# Altium schematic sheet Power.SchDoc(Power)
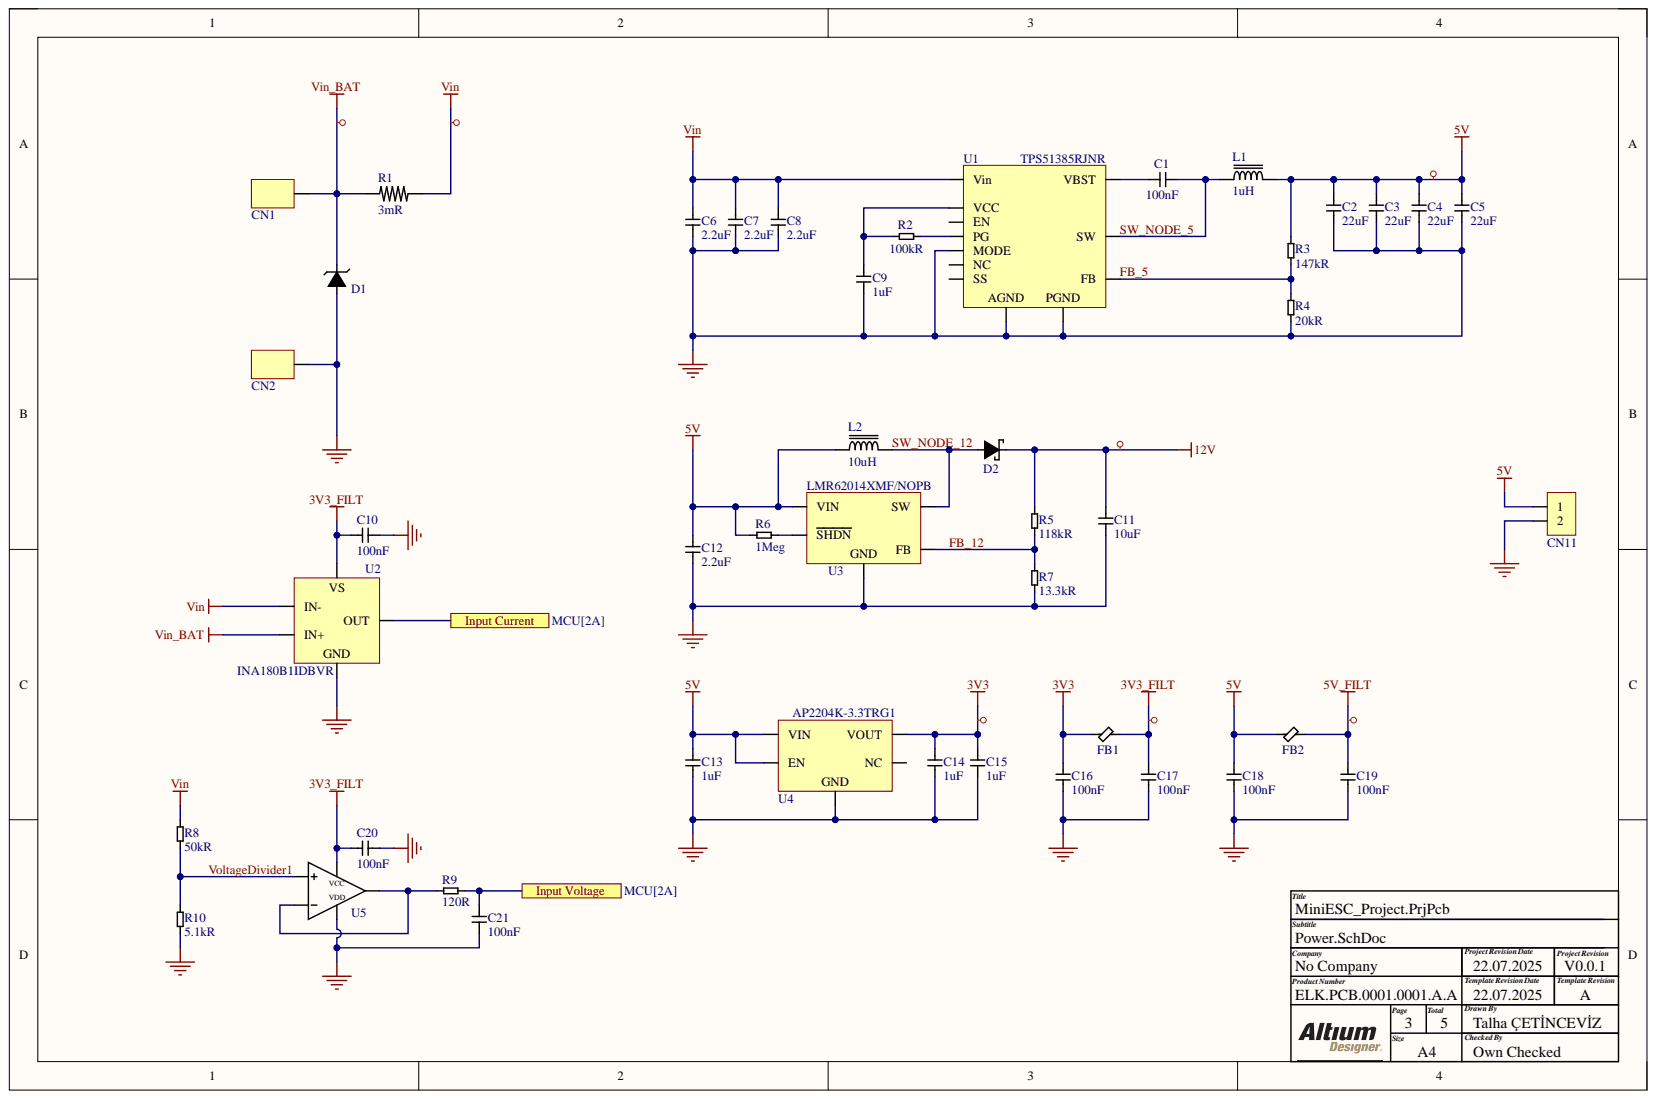
[diagram p0: Power.SchDoc]

## Parts
C1  value 100nF  [CAP 0805]  (hidden comment: CL21B104KBCNNNC)
C2  value 22uF  [CAP 1206]  (hidden comment: CL31B226KPHNNNE)
C3  value 22uF  [CAP 1206]  (hidden comment: CL31B226KPHNNNE)
C4  value 22uF  [CAP 1206]  (hidden comment: CL31B226KPHNNNE)
C5  value 22uF  [CAP 1206]  (hidden comment: CL31B226KPHNNNE)
C6  value 2.2uF  [CAP 1206]  (hidden comment: CL31B225KBHNNNE)
C7  value 2.2uF  [CAP 1206]  (hidden comment: CL31B225KBHNNNE)
C8  value 2.2uF  [CAP 1206]  (hidden comment: CL31B225KBHNNNE)
C9  value 1uF  [CAP 0805]  (hidden comment: CC0805KKX7R9BB105)
C10  value 100nF  [CAP 0603]  (hidden comment: CC0603KRX7R9BB104)
C11  value 10uF  [CAP 0805]  (hidden comment: CL21A106KAYNNNE)
C12  value 2.2uF  [CAP 1206]  (hidden comment: CL31B225KBHNNNE)
C13  value 1uF  [CAP 1206]  (hidden comment: CL31B105KOFNNNE)
C14  value 1uF  [CAP 1206]  (hidden comment: CL31B105KOFNNNE)
C15  value 1uF  [CAP 1206]  (hidden comment: CL31B105KOFNNNE)
C16  value 100nF  [CAP 0603]  (hidden comment: CC0603KRX7R9BB104)
C17  value 100nF  [CAP 0603]  (hidden comment: CC0603KRX7R9BB104)
C18  value 100nF  [CAP 0603]  (hidden comment: CC0603KRX7R9BB104)
C19  value 100nF  [CAP 0603]  (hidden comment: CC0603KRX7R9BB104)
C20  value 100nF  [CAP 0603]  (hidden comment: CC0603KRX7R9BB104)
C21  value 100nF  [CAP 0603]  (hidden comment: CC0603KRX7R9BB104)
CN1  SMD PAD 1  [SMD PAD 1]  (value not drawn)  (no BOM)
CN2  SMD PAD 1  [SMD PAD 1]  (value not drawn)  (no BOM)
CN11  2 Pin SMD  [2 Pin SMD]  (value not drawn)  (no BOM)
D1  SMAJ33CA-HT  [SMA]  (value not drawn)
D2  SL02-GS08  [DO-219AB]  (value not drawn)
FB1  BLM18EG601SN1D  [FB 0603]  (value not drawn)
FB2  BLM18EG601SN1D  [FB 0603]  (value not drawn)
L1  value 1uH  [11x10]  (hidden comment: MGAH10041R0M-10)
L2  value 10uH  [3.9x3.9]  (hidden comment: LPS4018-103MRC)
R1  value 3mR  [3920]  (hidden comment: BVS-A-R003-1.0)
R2  value 100kR  [RES 0603]  (hidden comment: RC0603FR-07100KL)
R3  value 147kR  [RES 0603]  (hidden comment: 0603SAF1473T5E)
R4  value 20kR  [RES 0603]  (hidden comment: 0603SAF2002T5E)
R5  value 118kR  [RES 0603]  (hidden comment: 0603SAF1183T5E)
R6  value 1Meg  [RES 0603]  (hidden comment: 0603SAJ0105T5E)
R7  value 13.3kR  [RES 0603]  (hidden comment: RC0603FR-0713K3L)
R8  value 50kR  [RES 0805]  (hidden comment: 0805S8F5002T5E)
R9  value 120R  [RES 0603]  (hidden comment: 0603SAF1200T5E)
R10  value 5.1kR  [RES 0603]  (hidden comment: 0603SAF5101T5E)
U1  TPS51385RJNR  [PWQFN-12]
U2  INA180B1IDBVR  [SOT23-5]
U3  LMR62014XMF/NOPB  [SOT23-5]
U4  AP2204K-3.3TRG1  [SOT23-5]
U5  LMV321ILT  [SOT23-5]  (value not drawn)

## Ports
Input Current, Input Voltage

## Nets
3V3: C14.2 C15.2 C16.2 FB1.1 U4.5(VOUT)
3V3_FILT: C10.1 C17.2 C20.1 FB1.2 U2.5(VS) U5.5
5V: C2.2 C3.2 C4.2 C5.2 C12.2 C13.2 C18.2 CN11.1 FB2.1 L1.2 L2.1 R3.2 R6.1 U3.5(VIN) U4.1(VIN) U4.3(EN)
5V_FILT: C19.2 FB2.2
12V: C11.2 D2.C R5.2
GND: C2.1 C3.1 C4.1 C5.1 C6.1 C7.1 C8.1 C9.1 C10.2 C11.1 C12.1 C13.1 C14.1 C15.1 C16.1 C17.1 C18.1 C19.1 C20.2 C21.1 CN2.1 CN11.2 D1.A R4.1 R7.1 R10.1 U1.2 U1.10 U1.12(MODE) U2.2 U3.2 U4.2 U5.2
Vin: C6.2 C7.2 C8.2 R1.2 R8.2 U1.1(Vin) U2.4(IN-)
Vin_BAT: CN1.1 D1.C R1.1 U2.3(IN+)
FB_5: R3.1 R4.2 U1.4(FB)
FB_12: R5.1 R7.2 U3.3(FB)
Input Current: U2.1(OUT) ; port Input Current
Input Voltage: C21.2 R9.2 ; port Input Voltage
SW_NODE_5: C1.2 L1.1 U1.7(SW)
SW_NODE_12: D2.A L2.2 U3.1(SW)
VoltageDivider1: R8.1 R10.2 U5.1
NetC1_1: C1.1 U1.8(VBST)
NetC9_2: C9.2 R2.1 U1.9(VCC)
NetR2_2: R2.2 U1.3(PG)
NetR6_2: R6.2 U3.4(SHDN)
NetR9_1: R9.1 U5.3 U5.4

Not connected: U1 5(SS) 6(NC) 11(EN); U4 4(NC)

## Library data of the parts
C1 = CL21B104KBCNNNC: 50V 100nF X7R ±10% 0805 Multilayer Ceramic Capacitors MLCC - SMD/SMT ROHS; Manufacturer: Samsung; Case Code (Metric): 2012; Case Code (Imperial): 0805; Case/Package: 0805; Category: Ceramic Capacitors; Component Kind: Standard; ComponentLink1Description: https://datasheet.ciiva.com/25710/cl21b104kbcnnnc-25710182.pdf?src-supplier=Digikey; ComponentLink1URL: https://datasheet.ciiva.com/25710/cl21b104kbcnnnc-25710182.pdf?src-supplier=Digikey; ComponentLink2Description: https://datasheet.ciiva.com/29199/454152-da-01-en-vs-kondensator-0805-29199814.pdf?src-supplier=Conrad; ComponentLink2URL: https://datasheet.ciiva.com/29199/454152-da-01-en-vs-kondensator-0805-29199814.pdf?src-supplier=Conrad; Construction: Flat; Depth: 1.25mm; Dielectric: X7R; Height: 0.85mm; Lead Free: 5697LeadFree; Length: 2mm; Library Name: ESC_Int.IntLib; Manufacturer Part Number: CL21B104KBCNNNC; Material: Ceramic; Max Operating Temperature: 125°C; Min Operating Temperature: -55°C; Mounting Technology: Surface Mount; Packaging: Tape and Reel; Pricing: 4000=0,00539, 8000=0,00525, 16000=0,00508, 24000=0,0049, 32000=0,00473 (USD); Radiation Hardening: No; RoHS: Yes; RoHS Compliant: Yes; Series: CL; Stock: 648000; Supplier: Ozdisan; Supplier Part Number: CL21B104KBCNNNC; Thickness: 0.037inch; Tolerance: 10%; Voltage: 50V; Voltage Rating: 50V; Voltage Rating (DC): 50V; Width: 0.049inch
C2-C5 = CL31B226KPHNNNE: Multilayer Ceramic Capacitor, 22 uF, 10 V, ± 10%, X7R, 1206 [3216 Metric]; Manufacturer: Samsung; Material: 4241ConductorMaterial; Voltage Rating: 10V; Case Code (Metric): 3216; Case Code (Imperial): 1206; Case/Package: 1206; Category: Ceramic Capacitors; Component Kind: Standard; ComponentLink1Description: https://datasheet.ciiva.com/25724/cl31b226kphnnne-25724443.pdf?src-supplier=Digikey; ComponentLink1URL: https://datasheet.ciiva.com/25724/cl31b226kphnnne-25724443.pdf?src-supplier=Digikey; ComponentLink2Description: https://datasheet.ciiva.com/16384/cl31b226kphnnne-16384588.pdf?src-supplier=Future+Electronics; ComponentLink2URL: https://datasheet.ciiva.com/16384/cl31b226kphnnne-16384588.pdf?src-supplier=Future+Electronics; ComponentLink3Description: https://datasheet.ciiva.com/26838/getdatasheetpartid-710483-26838941.pdf?src-supplier=Verical; ComponentLink3URL: https://datasheet.ciiva.com/26838/getdatasheetpartid-710483-26838941.pdf?src-supplier=Verical; Depth: 1.6mm; Dielectric: X7R; Lead Free: 5697LeadFree; Length: 0.126inch; Library Name: ESC_Int.IntLib; Manufacturer Part Number: CL31B226KPHNNNE; Max Operating Temperature: 125°C; Min Operating Temperature: -55°C; Mounting Technology: Surface Mount; Package Quantity: 1; Packaging: Tape and Reel; Pricing: 2000=0,105, 4000=0,102, 8000=0,099, 12000=0,096, 20000=0,093 (USD); Radiation Hardening: No; RoHS: Yes; RoHS Compliant: Yes; Series: CL; Stock: 10000; Supplier: Ozdisan; Supplier Part Number: CL31B226KPHNNNE; Thickness: 0.071inch; Tolerance: 10%; Voltage Rating (DC): 10V; Width: 0.063inch
C6-C8 C12 = CL31B225KBHNNNE: 50V 2.2uF X7R ±10% 1206 Multilayer Ceramic Capacitors MLCC - SMD/SMT ROHS; Manufacturer: Samsung; Case Code (Metric): 3216; Case Code (Imperial): 1206; Case/Package: 1206; Category: Ceramic Capacitors; Component Kind: Standard; ComponentLink1Description: https://datasheet.ciiva.com/25710/cl31b225kbhnnne-25710916.pdf?src-supplier=Digikey; ComponentLink1URL: https://datasheet.ciiva.com/25710/cl31b225kbhnnne-25710916.pdf?src-supplier=Digikey; ComponentLink2Description: https://datasheet.ciiva.com/26838/getdatasheetpartid-354100-26838937.pdf?src-supplier=Verical; ComponentLink2URL: https://datasheet.ciiva.com/26838/getdatasheetpartid-354100-26838937.pdf?src-supplier=Verical; ComponentLink3Description: https://datasheet.ciiva.com/26310/mlcc-catalogdownload2013-eng-26310094.pdf?src-supplier=Distrelec; ComponentLink3URL: https://datasheet.ciiva.com/26310/mlcc-catalogdownload2013-eng-26310094.pdf?src-supplier=Distrelec; Construction: Flat; Depth: 1.6mm; Dielectric: X7R; Height: 1.6mm; Lead Free: Lead Free; Length: 3.2mm; Library Name: ESC_Int.IntLib; Manufacturer Part Number: CL31B225KBHNNNE; Material: Ceramic; Max Operating Temperature: 125°C; Min Operating Temperature: -55°C; Mounting Technology: Surface Mount; Packaging: Tape and Reel; Pricing: 2000=0,0366, 4000=0,0357, 6000=0,0352, 10000=0,0324, 14000=0,0321, 20000=0,0309, 50000=0,0284, 100000=0,0278, 200000=0,0265 (USD); Radiation Hardening: No; RoHS: Yes; RoHS Compliant: Yes; Series: CL; Stock: 1046000; Supplier: Ozdisan; Supplier Part Number: CL31B225KBHNNNE; Tolerance: 10%; Voltage: 50V; Voltage Rating: 50V; Voltage Rating (DC): 50V
C9 = CC0805KKX7R9BB105: Ceramic Capacitor, Ceramic, 50V, 10% +Tol, 10% -Tol, X7R, 15% TC, 1uF, Surface Mount, 0805; Manufacturer: Yageo; Material: 4241ConductorMaterial; Case Code (Metric): 2012; Case Code (Imperial): 0805; Case/Package: 0805; Category: Ceramic Capacitors; Component Kind: Standard; ComponentLink1Description: https://datasheet.ciiva.com/13209/upy-gphc-x7r-6-3v-to-50v-8-12304-13209375.pdf?src-supplier=Mouser; ComponentLink1URL: https://datasheet.ciiva.com/13209/upy-gphc-x7r-6-3v-to-50v-8-12304-13209375.pdf?src-supplier=Mouser; Dielectric: X7R; Halogen Free: 5694HalogenFree; Lead Free: 5697LeadFree; Library Name: ESC_Int.IntLib; Manufacturer Part Number: CC0805KKX7R9BB105; Max Operating Temperature: 125°C; Min Operating Temperature: -55°C; Mounting Technology: Surface Mount; Packaging: Tape and Reel; Pricing: 3000=0,009, 12000=0,0087, 30000=0,0085, 75000=0,0083, 120000=0,008 (USD); RoHS: Yes; RoHS Compliant: Yes; Series: CC; Stock: 369000; Supplier: Ozdisan; Supplier Part Number: CC0805KKX7R9BB105; Termination: SMD/SMT; Tolerance: 10%; Voltage Rating: 50V; Voltage Rating (DC): 50V; Weight: 0.000194oz
C10 C16-C21 = CC0603KRX7R9BB104: Multilayer Ceramic Capacitor, CC Series, 0.1 - F, - 10%, X7R, 50 V, 0603 [1608 Metric]; Manufacturer: Yageo; Dielectric Material: 4241ConductorMaterial; Voltage: 50V; Case Code (Metric): 1608; Case Code (Imperial): 0603; Case/Package: 0603; Category: Ceramic Capacitors; Component Kind: Standard; ComponentLink1Description: https://datasheet.ciiva.com/11786/0900766b80d84f1d-11786061.pdf?src-supplier=Rs; ComponentLink1URL: https://datasheet.ciiva.com/11786/0900766b80d84f1d-11786061.pdf?src-supplier=Rs; ComponentLink2Description: https://datasheet.ciiva.com/8033/70765-8033821.pdf?src-supplier=Element14; ComponentLink2URL: https://datasheet.ciiva.com/8033/70765-8033821.pdf?src-supplier=Element14; ComponentLink3Description: https://datasheet.ciiva.com/7978/1489800-7978166.pdf?src-supplier=Element14; ComponentLink3URL: https://datasheet.ciiva.com/7978/1489800-7978166.pdf?src-supplier=Element14; ComponentLink4Description: https://datasheet.ciiva.com/144/mlccorderingcode-144177.pdf?src-supplier=Digikey; ComponentLink4URL: https://datasheet.ciiva.com/144/mlccorderingcode-144177.pdf?src-supplier=Digikey; ComponentLink5Description: https://datasheet.ciiva.com/144/mlccorderingcode-144176.pdf?src-supplier=Digikey; ComponentLink5URL: https://datasheet.ciiva.com/144/mlccorderingcode-144176.pdf?src-supplier=Digikey; ComponentLink6Description: https://datasheet.ciiva.com/144/mlccorderingcode-144178.pdf?src-supplier=Digikey; ComponentLink6URL: https://datasheet.ciiva.com/144/mlccorderingcode-144178.pdf?src-supplier=Digikey; ComponentLink7Description: https://datasheet.ciiva.com/8033/70765-8033819.pdf?src-supplier=Element14; ComponentLink7URL: https://datasheet.ciiva.com/8033/70765-8033819.pdf?src-supplier=Element14; ComponentLink8Description: https://datasheet.ciiva.com/31394/1489800-31394927.pdf?src-supplier=Farnell; ComponentLink8URL: https://datasheet.ciiva.com/31394/1489800-31394927.pdf?src-supplier=Farnell; ComponentLink9Description: https://datasheet.ciiva.com/22749/1489800-22749214.pdf?src-supplier=Element14; ComponentLink9URL: https://datasheet.ciiva.com/22749/1489800-22749214.pdf?src-supplier=Element14; Depth: 0.8mm; Dielectric: X7R; Halogen Free: 5694HalogenFree; Height: 0.8mm; Lead Free: 5697LeadFree; Length: 0.063inch; Library Name: ESC_Int.IntLib; Manufacturer Part Number: CC0603KRX7R9BB104; Material: 4241ConductorMaterial; Max Operating Temperature: 125°C; Min Operating Temperature: -55°C; Mounting Technology: Surface Mount; Package Quantity: 1; Packaging: Tape and Reel; Pins: 2; Pricing: 4000=0,0018, 20000=0,0017, 80000=0,0017, 200000=0,0016, 500000=0,0015 (USD); Radiation Hardening: No; Reach SVHC Compliant: No; RoHS: Yes; RoHS Compliant: Yes; Series: CC; Stock: 5600000; Supplier: Ozdisan; Supplier Part Number: CC0603KRX7R9BB104; Termination: SMD/SMT; Thickness: 0.035inch; Tolerance: 10%; Voltage Rating: 50V; Width: 0.031inch
C11 = CL21A106KAYNNNE: 25V 10uF X5R ±10% 0805 Multilayer Ceramic Capacitors MLCC - SMD/SMT ROHS; Manufacturer: Samsung; Case Code (Metric): 2012; Case Code (Imperial): 0805; Case/Package: 0805; Category: Ceramic Capacitors; Component Kind: Standard; ComponentLink1Description: https://datasheet.ciiva.com/25796/cl21a106kaynnne-25796720.pdf?src-supplier=Digikey; ComponentLink1URL: https://datasheet.ciiva.com/25796/cl21a106kaynnne-25796720.pdf?src-supplier=Digikey; ComponentLink2Description: https://datasheet.ciiva.com/26838/getdatasheetpartid-357558-26838583.pdf?src-supplier=Verical; ComponentLink2URL: https://datasheet.ciiva.com/26838/getdatasheetpartid-357558-26838583.pdf?src-supplier=Verical; Construction: Flat; Depth: 1.25mm; Dielectric: X5R; Height: 1.25mm; Length: 2mm; Library Name: ESC_Int.IntLib; Manufacturer Part Number: CL21A106KAYNNNE; Material: Ceramic; Max Operating Temperature: 85°C; Min Operating Temperature: -55°C; Mounting Technology: Surface Mount; Package Quantity: 1; Packaging: Tape and Reel; Pricing: 2000=0,00823 (USD); Radiation Hardening: No; RoHS: Yes; RoHS Compliant: Yes; Series: CL; Stock: 3442000; Supplier: Ozdisan; Supplier Part Number: CL21A106KAYNNNE; Thickness: 0.057inch; Tolerance: 10%; Voltage Rating: 25V; Voltage Rating (DC): 25V; Width: 0.049inch
C13-C15 = CL31B105KOFNNNE: Cap Ceramic 1uF 16V X7R 10% SMD 1206 125°C Embossed T/R; Manufacturer: Samsung; Tolerance: %10; Case/Package: 1206; Category: Ceramic Capacitors; Component Kind: Standard; ComponentLink1Description: https://datasheet.ciiva.com/25798/cl31b105kofnnne-25798673.pdf?src-supplier=Digikey; ComponentLink1URL: https://datasheet.ciiva.com/25798/cl31b105kofnnne-25798673.pdf?src-supplier=Digikey; ComponentLink2Description: https://datasheet.ciiva.com/26838/getdatasheetpartid-358370-26838906.pdf?src-supplier=Verical; ComponentLink2URL: https://datasheet.ciiva.com/26838/getdatasheetpartid-358370-26838906.pdf?src-supplier=Verical; ComponentLink3Description: https://datasheet.ciiva.com/29200/453334-da-01-en-vs-kondensator-1206-29200316.pdf?src-supplier=Conrad; ComponentLink3URL: https://datasheet.ciiva.com/29200/453334-da-01-en-vs-kondensator-1206-29200316.pdf?src-supplier=Conrad; ComponentLink4Description: https://datasheet.ciiva.com/26310/mlcc-catalogdownload2013-eng-26310089.pdf?src-supplier=Distrelec; ComponentLink4URL: https://datasheet.ciiva.com/26310/mlcc-catalogdownload2013-eng-26310089.pdf?src-supplier=Distrelec; Dielectric: X7R; Library Name: ESC_Int.IntLib; Manufacturer Part Number: CL31B105KOFNNNE; Material: Ceramic; Max Operating Temperature: 125°C; Min Operating Temperature: -55°C; Pricing: 2000=0,01378, 4000=0,01343, 8000=0,01083, 12000=0,01253, 16000=0,01208 (USD); RoHS: Yes; Stock: 124000; Supplier: Ozdisan; Supplier Part Number: CL31B105KOFNNNE; Voltage Rating (DC): 16V
D1 = SMAJ33CA-HT: DIODE TVS 400W 33V DO214AC SMA; Manufacturer: Taiwan Semiconductor; Manufacturer Part Number: SMAJ33CAH; Case/Package: SMA; Category: TVS Diode; Component Kind: Standard; Library Name: ESC_Int.IntLib; Max Operating Temperature: 150°C; Min Operating Temperature: -55°C; Pricing: 1=0,5, 10=0,31, 50=0,31, 100=0,197, 1000=0,119, 10000=0,096 (USD); RoHS: No; Stock: 97379; Supplier: Ozdisan; Supplier Part Number: SMAJ33CA-HT
D2 = SL02-GS08: Rectifier Diode Schottky 20V 1.1A 10ns Automotive 2-Pin SMF T/R; Manufacturer: Vishay; Max Forward Surge Current (Ifsm): 40A; Max Reverse Current: 250uA; Average Rectified Current: 1.1A; Case/Package: DO-219AB; Category: Rectifier Diodes; Component Kind: Standard; ComponentLink1Description: https://datasheet.ciiva.com/8290/sl02-8290576.pdf?src-supplier=Avnet; ComponentLink1URL: https://datasheet.ciiva.com/8290/sl02-8290576.pdf?src-supplier=Avnet; ComponentLink2Description: https://datasheet.ciiva.com/4714/85687-201208-4714309.pdf?src-supplier=Mouser; ComponentLink2URL: https://datasheet.ciiva.com/4714/85687-201208-4714309.pdf?src-supplier=Mouser; ComponentLink3Description: https://datasheet.ciiva.com/25932/sl02-25932747.pdf?; ComponentLink3URL: https://datasheet.ciiva.com/25932/sl02-25932747.pdf?; Forward Current: 1.1A; Library Name: ESC_Int.IntLib; Manufacturer Part Number: SL02-GS08; Max Operating Temperature: 125°C; Max Repetitive Reverse Voltage (Vrrm): 20V; Max Reverse Voltage (DC): 20V; Min Operating Temperature: -55°C; Mounting Technology: Surface Mount; Package Quantity: 1; Packaging: Cut Tape; Peak Non-Repetitive Surge Current: 40A; Pins: 2; Pricing: 1=0,25, 10=0,192, 50=0,192, 100=0,184, 1000=0,121, 10000=0,095 (USD); Reach SVHC Compliant: Unknown; Reverse Recovery Time: 10ns; RoHS: Yes; RoHS Compliant: Yes; Stock: 30181; Supplier: Ozdisan; Supplier Part Number: SL02-GS08
FB1 FB2 = BLM18EG601SN1D: Ferrite Beads Multi-Layer 600Ohm 25% 100MHz 500mA 350mOhm DCR 0603 Paper T/R; Manufacturer: Murata; Manufacturer Series: BLM18E; Channels: 1; DC Resistance (DCR): 350mR; Material: Steel,Ferrite; Shielding: 202Shielding; Value: 350mR; Impedance: 600R; Case Code (Metric): 1608; Case Code (Imperial): 0603; Case/Package: 0603; Category: Ferrite Beads and Chips; Component Kind: Standard; ComponentLink1Description: https://datasheet.ciiva.com/3854/l0110s0101blm18e-3854062.pdf?src-supplier=Arrow+Electronics; ComponentLink1URL: https://datasheet.ciiva.com/3854/l0110s0101blm18e-3854062.pdf?src-supplier=Arrow+Electronics; ComponentLink2Description: https://datasheet.ciiva.com/18093/getdatasheetpartid-152954-18093061.pdf?src-supplier=Verical; ComponentLink2URL: https://datasheet.ciiva.com/18093/getdatasheetpartid-152954-18093061.pdf?src-supplier=Verical; ComponentLink3Description: https://datasheet.ciiva.com/36526/1844942-36526047.pdf?src-supplier=Jameco; ComponentLink3URL: https://datasheet.ciiva.com/36526/1844942-36526047.pdf?src-supplier=Jameco; ComponentLink4Description: https://datasheet.ciiva.com/11799/0900766b811b5866-11799831.pdf?src-supplier=Rs; ComponentLink4URL: https://datasheet.ciiva.com/11799/0900766b811b5866-11799831.pdf?src-supplier=Rs; ComponentLink5Description: https://datasheet.ciiva.com/41449/001172270-da-01-en-drossel-0603-600r-1-6x0-8mm-4000st-41449585.pdf?src-supplier=Conrad; ComponentLink5URL: https://datasheet.ciiva.com/41449/001172270-da-01-en-drossel-0603-600r-1-6x0-8mm-4000st-41449585.pdf?src-supplier=Conrad; ComponentLink6Description: https://datasheet.ciiva.com/1467/5169-1467238.pdf?src-supplier=Element14; ComponentLink6URL: https://datasheet.ciiva.com/1467/5169-1467238.pdf?src-supplier=Element14; ComponentLink7Description: https://datasheet.ciiva.com/1012/l0110s0101blm18e-1012876.pdf?src-supplier=Digikey; ComponentLink7URL: https://datasheet.ciiva.com/1012/l0110s0101blm18e-1012876.pdf?src-supplier=Digikey; ComponentLink8Description: https://datasheet.ciiva.com/1012/l0110s0101blm18e-1012875.pdf?src-supplier=Digikey; ComponentLink8URL: https://datasheet.ciiva.com/1012/l0110s0101blm18e-1012875.pdf?src-supplier=Digikey; ComponentLink9Description: https://datasheet.ciiva.com/1012/l0110s0101blm18e-1012877.pdf?src-supplier=Digikey; ComponentLink9URL: https://datasheet.ciiva.com/1012/l0110s0101blm18e-1012877.pdf?src-supplier=Digikey; ComponentLink10Description: https://datasheet.ciiva.com/8084/l0110s0101blm18e-8084284.pdf?src-supplier=Avnet; ComponentLink10URL: https://datasheet.ciiva.com/8084/l0110s0101blm18e-8084284.pdf?src-supplier=Avnet; ComponentLink11Description: https://datasheet.ciiva.com/16200/l0110s0101blm18e-16200310.pdf?src-supplier=Future+Electronics; ComponentLink11URL: https://datasheet.ciiva.com/16200/l0110s0101blm18e-16200310.pdf?src-supplier=Future+Electronics; ComponentLink12Description: https://datasheet.ciiva.com/3081/l0110s0101blm18e-3081626.pdf?src-supplier=Avnet; ComponentLink12URL: https://datasheet.ciiva.com/3081/l0110s0101blm18e-3081626.pdf?src-supplier=Avnet; ComponentLink13Description: https://datasheet.ciiva.com/1467/5169-1467239.pdf?src-supplier=Element14; ComponentLink13URL: https://datasheet.ciiva.com/1467/5169-1467239.pdf?src-supplier=Element14; Core Material: Ferrite; Current Rating: 500mA; DC Current: 500mA; DC Resistance-Max: 350mR; Depth: 0.8mm; ESR: 350mR; Frequency: 100MHz; Height: 0.8mm; Lead Free: Lead Free; Length: 1.6mm; Library Name: ESC_Int.IntLib; Manufacturer Part Number: BLM18EG601SN1D; Max Current Rating: 500mA; Max DC Current: 500mA; Max Operating Temperature: 125°C; Military Standard: Not; Min Operating Temperature: -55°C; Number of Circuits: 1; Number of Lines: 1; Package Quantity: 1; Packaging: Tape and Reel; Pins: 2; Pricing: 4000=0,0204, 12000=0,0199, 20000=0,0196, 40000=0,0193, 80000=0,0189 (USD); Radiation Hardening: No; Reach SVHC Compliant: No; RMS Current (Irms): 500mA; RoHS: Yes; RoHS Compliant: Yes; Series: BLM18E; Stock: 324000; Supplier: Ozdisan; Supplier Part Number: BLM18EG601SN1D; Terminal Finish: Tin; Termination: SMD/SMT; Test Frequency: 100MHz; Tolerance: 25%; Width: 0.8mm
L1 = MGAH10041R0M-10: 1 µH Shielded Molded Inductor 18 A 3.3mOhm Max Nonstandard; Manufacturer: Laird; Core Material: Metal Composite; Current - Saturation (Isat): 28 A; DC Resistance (DCR): 3.3 m R; Max DC Current: 18 A; Tolerance: 20%; Case/Package: 11x10; Category: Inductors; Component Kind: Standard; Height: 4.1 mm; Length: 11 mm; Library Name: ESC_Int.IntLib; Manufacturer Part Number: MGAH10041R0M-10; Max Operating Temperature: 155°C; Min Operating Temperature: -55°C; Pricing: 8000=0,52057, 16000=0,49243, 32000=0,47947, 48000=0,42987, 64000=0,41913 (USD); RoHS: No; Self Resonant Frequency: -; Shielding: Shielded; Supplier: Digikey; Supplier Part Number: 240-MGAH10041R0M-10TR-ND; Width: 10 mm
L2 = LPS4018-103MRC: Power inductor, shielded, 20/30% tol, SMT, RoHS, lead-free, halogen-free; Manufacturer: Coilcraft; Core Material: Ferrite; Max DC Current: 1.3A; Self Resonant Frequency: 40MHz; Test Frequency: 100kHz; Tolerance: 20%; Case/Package: 3.9x3.9; Category: Inductors; Component Kind: Standard; ComponentLink1Description: http://www.mouser.com/ds/2/597/lps4018-270702.pdf?src-supplier=Mouser; ComponentLink1URL: http://www.mouser.com/ds/2/597/lps4018-270702.pdf?src-supplier=Mouser; Height: 1.7mm; Length: 4mm; Library Name: ESC_Int.IntLib; Manufacturer Part Number: LPS4018-103MRC; Max Operating Temperature: 85°C; Min Operating Temperature: -40°C; Package Quantity: 1000; Packaging: Tape and Reel; Pricing: 1=1,46, 10=1,46, 50=1,46, 100=1,46, 1000=0,745, 10000=0,744 (USD); RoHS: No; RoHS Compliant: No; Series: LPS4018; Shielding: Shielded; Stock: 11; Supplier: Digikey; Supplier Part Number: 2457-LPS4018-103MRC-ND; Termination: SMD/SMT; Weight: 0.003527oz; Width: 4mm
R1 = BVS-A-R003-1.0: RES.SHUNT 3920 3m Ohms 1% 5W AUTO ALUCHROM SMT; Manufacturer: Isabellenhütte; Case/Package: 3920; Component Kind: Standard; Library Name: ESC_Int.IntLib; Manufacturer Part Number: BVS-A-R003-1.0; Max Operating Temperature: 170°C; Min Operating Temperature: -65°C; Pricing: 1=2,8765, 10=1,9428, 25=1,5178, 100=1,2221, 500=1,1183, 1000=0,8522 (USD); RoHS: Yes; Stock: 900; Supplier: Ozdisan; Supplier Part Number: BVS-A-R003-1.0
R2 = RC0603FR-07100KL: 100Mw Thick Film Resistors 100Ppm/ 1% 100K 0603 Chip Resistor Surface Mount Rohs; Manufacturer: Yageo; Temperature Coefficient: 100ppm/°C; Voltage Rating: 50V; Case Code (Metric): 1608; Case Code (Imperial): 0603; Case/Package: 0603; Category: Chip SMD Resistors; Component Kind: Standard; Construction: Rectangular; Depth: 0.8mm; Features: Moisture Resistant; Height: 0.45mm; Lead Free: 5697LeadFree; Length: 1.6mm; Library Name: ESC_Int.IntLib; Manufacturer Part Number: RC0603FR-07100KL; Max Operating Temperature: 155°C; Max Power Dissipation: 100mW; Military Standard: Not; Min Operating Temperature: -55°C; Number of Terminations: 2; Packaging: Tape and Reel; Pins: 2; Power Rating: 100mW; Pricing: 5000=0,001, 25000=0,001, 150000=0,001, 500000=0,0009, 1500000=0,0009 (USD); Reach SVHC Compliant: No; Resistance Tolerance: 1%; Resistor Type: Thick Film; RoHS: Yes; RoHS Compliant: Yes; Series: RC; Stock: 9150000; Supplier: Ozdisan; Supplier Part Number: RC0603FR-07100KL; Technology: THICK FILM; Termination: SMD/SMT; Tolerance: 1%; Voltage Rating (DC): 50V; Width: 0.8mm
R3 = 0603SAF1473T5E: RES.(1608) 0603 147K Ohms 1% 1/10W-S 100PPM; Manufacturer: Multicomp; Manufacturer Part Number: MC0603SAF1473T5E; Power Rating: 100mW; Temperature Coefficient: 100ppm/°C; Voltage Rating: 75V; Case Code (Metric): 1608; Case Code (Imperial): 0603; Case/Package: 0603; Category: Uncategorized; Component Kind: Standard; Library Name: ESC_Int.IntLib; Max Operating Temperature: 155°C; Min Operating Temperature: -55°C; Packaging: Cut Tape; Pins: 2; Reach SVHC Compliant: Unknown; Resistance Tolerance: 1%; Resistor Type: Thick Film; RoHS Compliant: Yes; Supplier: Ozdisan; Supplier Part Number: 0603SAF1473T5E; Voltage Rating (DC): 75V
R4 = 0603SAF2002T5E: RES.(1608) 0603 20K Ohms 1% 1/10W-S 100PPM; Manufacturer: Royal Electronic Factory; Power Rating: 100mW; Temperature Coefficient: ±100PPM/°C; Voltage Rating (DC): 75 V; Category: LDO; Component Kind: Standard; ComponentLink1Description: https://datasheet.octopart.com/0603SAF2002T5E-Royal-Ohm-datasheet-165785611.pdf?src-supplier=TME; ComponentLink1URL: https://datasheet.octopart.com/0603SAF2002T5E-Royal-Ohm-datasheet-165785611.pdf?src-supplier=TME; ComponentLink2Description: https://datasheet.ciiva.com/7793/ta-677-7793947.pdf?src-supplier=Element14; ComponentLink2URL: https://datasheet.ciiva.com/7793/ta-677-7793947.pdf?src-supplier=Element14; Library Name: ESC_Int.IntLib; Manufacturer Part Number: 0603SAF2002T5E; Max Operating Temperature: 155°C; Min Operating Temperature: -55°C; Pricing: 10000=0,001 (USD); Resistance Tolerance: 1%; RoHS: Yes; RoHS Compliant: Yes; Stock: 135000; Supplier: Ozdisan; Supplier Part Number: 0603SAF2002T5E
R5 = 0603SAF1183T5E: Resistor, Thick Film, 118 Kohm, 100MW, 1%; Manufacturer: Multicomp; Manufacturer Part Number: MC0603SAF1183T5E; Temperature Coefficient: 100ppm/°C; Voltage Rating: 75V; Case Code (Metric): 1608; Case Code (Imperial): 0603; Case/Package: 0603; Category: Resistors; Component Kind: Standard; Library Name: ESC_Int.IntLib; Max Operating Temperature: 155°C; Min Operating Temperature: -55°C; Packaging: Cut Tape; Pins: 2; Power Rating: 100mW; Reach SVHC Compliant: Unknown; Resistance Tolerance: 1%; Resistor Type: Thick Film; RoHS Compliant: Yes; Supplier: Ozdisan; Supplier Part Number: 0603SAF1183T5E; Voltage Rating (DC): 75V
R6 = 0603SAJ0105T5E: Res Thick Film 0603 1M Ohm 5% 0.1W(1/10W) ±200ppm/°C Pad SMD T/R; Manufacturer: Royal Electronic Factory; Power Rating: 100 mW; Resistance Tolerance: %5; Temperature Coefficient: 100 PPM; Voltage Rating (DC): 75V; Case/Package: 0603; Category: Resistors; Component Kind: Standard; Library Name: ESC_Int.IntLib; Manufacturer Part Number: 0603SAJ0105T5E; Max Operating Temperature: 155°C; Min Operating Temperature: -55°C; Pricing: 10000=0,001 (USD); RoHS: Yes; Stock: 115000; Supplier: Ozdisan; Supplier Part Number: 0603SAJ0105T5E
R7 = RC0603FR-0713K3L: SMD Chip Resistor, 13.3 kohm, ï¿½ 1%, 100 mW, 0603 [1608 Metric], Thick Film, General Purpose; Manufacturer: Yageo; Max Power Dissipation: 100mW; Temperature Coefficient: 100ppm/°C; Voltage Rating: 50V; Case Code (Metric): 1608; Case Code (Imperial): 0603; Case/Package: 0603; Category: Chip SMD Resistors; Component Kind: Standard; ComponentLink1Description: https://datasheet.ciiva.com/11819/0900766b80f96399-11819248.pdf?src-supplier=Rs; ComponentLink1URL: https://datasheet.ciiva.com/11819/0900766b80f96399-11819248.pdf?src-supplier=Rs; ComponentLink2Description: https://datasheet.ciiva.com/15189/getdatasheetpartid-323984-15189605.pdf?src-supplier=Verical; ComponentLink2URL: https://datasheet.ciiva.com/15189/getdatasheetpartid-323984-15189605.pdf?src-supplier=Verical; ComponentLink3Description: https://datasheet.ciiva.com/2454/pyu-r-int-thick-7-2454007.pdf?src-supplier=Digikey; ComponentLink3URL: https://datasheet.ciiva.com/2454/pyu-r-int-thick-7-2454007.pdf?src-supplier=Digikey; ComponentLink4Description: https://datasheet.ciiva.com/2454/pyu-r-int-thick-7-2454008.pdf?src-supplier=Digikey; ComponentLink4URL: https://datasheet.ciiva.com/2454/pyu-r-int-thick-7-2454008.pdf?src-supplier=Digikey; ComponentLink5Description: https://datasheet.ciiva.com/2454/pyu-r-int-thick-7-2454006.pdf?src-supplier=Digikey; ComponentLink5URL: https://datasheet.ciiva.com/2454/pyu-r-int-thick-7-2454006.pdf?src-supplier=Digikey; Construction: Rectangular; Depth: 0.8mm; Features: Moisture Resistant; Height: 0.45mm; Lead Free: Lead Free; Length: 1.6mm; Library Name: ESC_Int.IntLib; Manufacturer Part Number: RC0603FR-0713K3L; Max Operating Temperature: 155°C; Military Standard: Not; Min Operating Temperature: -55°C; Mounting Technology: Surface Mount; Number of Terminations: 2; Packaging: Tape and Reel; Power Rating: 100mW; Pricing: 5000=0,0011, 25000=0,001, 150000=0,001, 500000=0,001, 1500000=0,0009 (USD); RoHS: Yes; RoHS Compliant: Yes; Series: RC; Stock: 50000; Supplier: Ozdisan; Supplier Part Number: RC0603FR-0713K3L; Technology: THICK FILM; Termination: SMD/SMT; Tolerance: 1%; Voltage Rating (DC): 50V
R8 = 0805S8F5002T5E: RES.(2012) 0805 50K Ohms 1% 1/8W-S 100PPM; Manufacturer: ROYALOHM; Max Power Dissipation: 125mW; Temperature Coefficient: 100ppm/°C; Voltage Rating: 150V; Case Code (Metric): 2012; Case Code (Imperial): 0805; Case/Package: 0805; Category: Chip SMD Resistors; Component Kind: Standard; ComponentLink1Description: https://datasheet.ciiva.com/7660/38590-7660789.pdf?src-supplier=Element14; ComponentLink1URL: https://datasheet.ciiva.com/7660/38590-7660789.pdf?src-supplier=Element14; ComponentLink2Description: https://datasheet.ciiva.com/7983/443971-7983596.pdf?src-supplier=Element14; ComponentLink2URL: https://datasheet.ciiva.com/7983/443971-7983596.pdf?src-supplier=Element14; ComponentLink3Description: https://datasheet.ciiva.com/22747/443971-22747519.pdf?src-supplier=Element14; ComponentLink3URL: https://datasheet.ciiva.com/22747/443971-22747519.pdf?src-supplier=Element14; ComponentLink4Description: https://datasheet.ciiva.com/46440/1868787-46440891.pdf?src-supplier=Element14; ComponentLink4URL: https://datasheet.ciiva.com/46440/1868787-46440891.pdf?src-supplier=Element14; ComponentLink5Description: https://datasheet.ciiva.com/7746/1738695-7746239.pdf?src-supplier=Element14; ComponentLink5URL: https://datasheet.ciiva.com/7746/1738695-7746239.pdf?src-supplier=Element14; ComponentLink6Description: https://datasheet.ciiva.com/15189/getdatasheetpartid-409289-15189591.pdf?src-supplier=Verical; ComponentLink6URL: https://datasheet.ciiva.com/15189/getdatasheetpartid-409289-15189591.pdf?src-supplier=Verical; Features: Moisture Resistant; Library Name: ESC_Int.IntLib; Manufacturer Part Number: 0805S8F5002T5E; Max Operating Temperature: 155°C; Min Operating Temperature: -55°C; Number of Terminations: 2; Packaging: Tape and Reel; Pins: 2; Power Rating: 125mW; Resistance Tolerance: 1%; Resistor Type: Thick Film; RoHS: Yes; Series: RC; Supplier: Ozdisan; Supplier Part Number: 0805S8F5002T5E; Termination: SMD/SMT; Tolerance: 1%; Voltage Rating (DC): 150V
R9 = 0603SAF1200T5E: RES.(1608) 0603 120 Ohms 1% 1/10W-S 100PPM; Manufacturer: ROYALOHM; Max Power Dissipation: 100mW; Temperature Coefficient: 100ppm/°C; Voltage Rating: 75V; Voltage Rating (DC): 50V; Case Code (Metric): 1608; Case Code (Imperial): 0603; Case/Package: 0603; Category: Chip SMD Resistors; Component Kind: Standard; Construction: Rectangular; Depth: 0.8mm; Features: Moisture Resistant; Height: 0.45mm; Lead Pitch: 0.3mm; Length: 1.6mm; Library Name: ESC_Int.IntLib; Manufacturer Part Number: 0603SAF1200T5E; Max Operating Temperature: 155°C; Min Operating Temperature: -55°C; Number of Terminations: 2; Packaging: Tape and Reel; Pins: 2; Power Rating: 100mW; Resistance Tolerance: 1%; Resistor Type: Thick Film; RoHS: Yes; Series: RC; Supplier: Ozdisan; Supplier Part Number: 0603SAF1200T5E; Termination: SMD/SMT; Tolerance: 1%; Weight: 7.1E-05oz; Width: 0.8mm
R10 = 0603SAF5101T5E: Fixed Resistor, Metal Glaze/thick Film, 0.1W, 5100ohm, 50V, 1% +/-Tol, 0603; Manufacturer: Royal Electronic Factory; Power Rating: 100mW; Temperature Coefficient: 100ppm/°C; Voltage Rating (DC): 75V; Case/Package: 0603; Category: Resistors; Component Kind: Standard; ComponentLink1Description: https://datasheet.octopart.com/0603SAF5101T5E-Royal-Ohm-datasheet-165785611.pdf?src-supplier=TME; ComponentLink1URL: https://datasheet.octopart.com/0603SAF5101T5E-Royal-Ohm-datasheet-165785611.pdf?src-supplier=TME; ComponentLink2Description: https://datasheet.ciiva.com/7942/ta-677-7942905.pdf?src-supplier=Element14; ComponentLink2URL: https://datasheet.ciiva.com/7942/ta-677-7942905.pdf?src-supplier=Element14; Library Name: ESC_Int.IntLib; Manufacturer Part Number: 0603SAF5101T5E; Max Operating Temperature: 155°C; Min Operating Temperature: -55°C; Pricing: 10000=0,001 (USD); Resistance Tolerance: 1%; RoHS: No; Stock: 25000; Supplier: Ozdisan; Supplier Part Number: 0603SAF5101T5E
U1 = TPS51385RJNR: 4.5-V to 24-V input, 7-A synchronous step-down voltage regulator with on-time D-CAP3 control 12-VQFN-HR -40 to 125; Manufacturer: Texas Instruments; Case/Package: PVQFN-12; Component Kind: Standard; Length: 3mm; Library Name: ESC_Int.IntLib; Manufacturer Part Number: TPS51385RJNR; Max Operating Temperature: 125°C; Min Operating Temperature: -40°C; Pins: 12; Pricing: 1=0,95, 10=0,681, 50=0,615, 100=0,541, 1000=0,444, 10000=0,424 (USD); RoHS: No; Stock: 1650; Supplier: Digikey; Supplier Part Number: 296-TPS51385RJNRTR-ND; Thickness: 900um; Width: 2mm
U2 = INA180B1IDBVR: 26V, 350kHz current sense amplifier 5-SOT-23 -40 to 125; Manufacturer: Texas Instruments; Case/Package: SOT23-5; Category: Amplifiers - Op Amps, Buffer, Instrumentation; Component Kind: Standard; ComponentLink1Description: http://www.ti.com/general/docs/suppproductinfo.tsp?distId=10&amp;gotoUrl=http%3A%2F%2Fwww.ti.com%2Flit%2Fgpn%2Fina180&src-supplier=Digikey; ComponentLink1URL: http://www.ti.com/general/docs/suppproductinfo.tsp?distId=10&amp;gotoUrl=http%3A%2F%2Fwww.ti.com%2Flit%2Fgpn%2Fina180&src-supplier=Digikey; ComponentLink2Description: http://www.ti.com/lit/ds/symlink/ina180.pdf?src-supplier=Digikey; ComponentLink2URL: http://www.ti.com/lit/ds/symlink/ina180.pdf?src-supplier=Digikey; Library Name: ESC_Int.IntLib; Manufacturer Part Number: INA180B1IDBVR; Max Operating Temperature: 125°C; Min Operating Temperature: -40°C; Pricing: 1=0,37, 10=0,257, 50=0,229, 100=0,198, 1000=0,167, 10000=0,147 (USD); RoHS: Yes; Stock: 17672; Supplier: Ozdisan; Supplier Part Number: INA180B1IDBVR
U3 = LMR62014XMF/NOPB: SIMPLE SWITCHER® 2.7V to 14V, 1.4A Step-Up Regulator in SOT-23 Package 5-SOT-23 -40 to 125; Manufacturer: TI National Semiconductor; Number of Channels: 1NUMBER; Quiescent Current: 2mA; Case/Package: SOT23-5; Category: Voltage Regulators - Switching; Component Kind: Standard; ComponentLink1Description: https://datasheet.ciiva.com/22669/1716576-22669414.pdf?src-supplier=Element14; ComponentLink1URL: https://datasheet.ciiva.com/22669/1716576-22669414.pdf?src-supplier=Element14; ComponentLink2Description: https://datasheet.ciiva.com/23854/1777788-23854326.pdf?src-supplier=Newark; ComponentLink2URL: https://datasheet.ciiva.com/23854/1777788-23854326.pdf?src-supplier=Newark; ComponentLink3Description: https://datasheet.ciiva.com/3231/lmr62014-3231410.pdf?src-supplier=Avnet; ComponentLink3URL: https://datasheet.ciiva.com/3231/lmr62014-3231410.pdf?src-supplier=Avnet; ComponentLink4Description: https://datasheet.ciiva.com/4879/snvs735a-74121-4879731.pdf?src-supplier=Mouser; ComponentLink4URL: https://datasheet.ciiva.com/4879/snvs735a-74121-4879731.pdf?src-supplier=Mouser; ComponentLink5Description: https://datasheet.ciiva.com/6603/lmr62014-6603804.pdf?src-supplier=Digikey; ComponentLink5URL: https://datasheet.ciiva.com/6603/lmr62014-6603804.pdf?src-supplier=Digikey; ComponentLink6Description: https://datasheet.ciiva.com/6603/lmr62014-6603807.pdf?src-supplier=Digikey; ComponentLink6URL: https://datasheet.ciiva.com/6603/lmr62014-6603807.pdf?src-supplier=Digikey; Height: 1.45mm; Lead Free: 5697LeadFree; Length: 2.9mm; Library Name: ESC_Int.IntLib; Manufacturer Part Number: LMR62014XMF/NOPB; Max Operating Temperature: 125°C; Min Operating Temperature: -40°C; Pricing: 1=2,02, 10=1,29, 50=1,16, 100=0,948, 1000=0,657, 10000=0,561 (USD); RoHS: Yes; Stock: 13573; Supplier: Ozdisan; Supplier Part Number: LMR62014XMF/NOPB; Thickness: 1.2mm; Width: 1.6mm
U4 = AP2204K-3.3TRG1: Linear Voltage Regulator IC Positive Fixed 1 Output 3.3V 150mA SOT-23-5; Manufacturer: Diodes; Max Output current: 250mA; Max Supply Current: 2.5mA; Max Supply Voltage: 24V; Min Input Voltage: 2.3V; Number of Outputs: 1; Power Supply Rejection Ratio (PSRR): 60dB; Quiescent Current: 50uA; Reference Voltage: 1.24V; Case/Package: SOT23-5; Category: Voltage Regulators - Linear; Component Kind: Standard; Height: 1.3mm; Lead Free: Lead Free; Length: 3.02mm; Library Name: ESC_Int.IntLib; Manufacturer Part Number: AP2204K-3.3TRG1; Max Operating Temperature: 85°C; Min Operating Temperature: -40°C; Min Output Voltage: 3.3V; Mounting Technology: Surface Mount; Number of Regulators: 1; Output Configuration: Positive; Output Current: 150mA; Output Type: Fixed; Output Voltage: 3.3V; Package Quantity: 3000; Packaging: Tape and Reel; Pins: 5; Polarity: Positive; Pricing: 1=0,53, 10=0,328, 50=0,288, 100=0,233, 1000=0,159, 10000=0,115 (USD); RoHS: Yes; RoHS Compliant: Yes; Series: -; Stock: 71303; Supplier: Ozdisan; Supplier Part Number: AP2204K-3.3TRG1; Weight: 0.001058oz; Width: 1.7mm
U5 = LMV321ILT: LMV321 Series 6 V 1 MHz Low Power Rail to Rail Operational Amplifier - SOT-23-5; Manufacturer: STMicroelectronics; Case/Package: SOT23-5; Category: Amplifiers - Op Amps, Buffer, Instrumentation; Component Kind: Standard; ComponentLink1Description: https://datasheet.ciiva.com/41459/001185379-da-01-en-ic-op-amp-sgl-r-r-lmv321ilt-sot-23-5-stm-41459833.pdf?src-supplier=Conrad; ComponentLink1URL: https://datasheet.ciiva.com/41459/001185379-da-01-en-ic-op-amp-sgl-r-r-lmv321ilt-sot-23-5-stm-41459833.pdf?src-supplier=Conrad; ComponentLink2Description: https://datasheet.ciiva.com/16449/cd00079372-16449002.pdf?src-supplier=Future+Electronics; ComponentLink2URL: https://datasheet.ciiva.com/16449/cd00079372-16449002.pdf?src-supplier=Future+Electronics; ComponentLink3Description: https://datasheet.ciiva.com/1795/cd00079372-1795891.pdf?src-supplier=Digikey; ComponentLink3URL: https://datasheet.ciiva.com/1795/cd00079372-1795891.pdf?src-supplier=Digikey; ComponentLink4Description: https://datasheet.ciiva.com/1795/cd00079372-1795892.pdf?src-supplier=Digikey; ComponentLink4URL: https://datasheet.ciiva.com/1795/cd00079372-1795892.pdf?src-supplier=Digikey; ComponentLink5Description: https://datasheet.ciiva.com/1795/cd00079372-1795893.pdf?src-supplier=Digikey; ComponentLink5URL: https://datasheet.ciiva.com/1795/cd00079372-1795893.pdf?src-supplier=Digikey; ComponentLink6Description: https://datasheet.ciiva.com/11710/cd00079372-11710214.pdf?src-supplier=Avnet; ComponentLink6URL: https://datasheet.ciiva.com/11710/cd00079372-11710214.pdf?src-supplier=Avnet; ComponentLink7Description: https://datasheet.ciiva.com/8008/1733540-8008505.pdf?src-supplier=Element14; ComponentLink7URL: https://datasheet.ciiva.com/8008/1733540-8008505.pdf?src-supplier=Element14; ComponentLink8Description: https://datasheet.ciiva.com/8008/1733540-8008506.pdf?src-supplier=Element14; ComponentLink8URL: https://datasheet.ciiva.com/8008/1733540-8008506.pdf?src-supplier=Element14; Lead Free: 5697LeadFree; Library Name: ESC_Int.IntLib; Manufacturer Part Number: LMV321ILT; Max Operating Temperature: 125°C; Min Operating Temperature: -40°C; Pricing: 3000=0,0711, 9000=0,0694, 15000=0,0686, 45000=0,0669, 75000=0,0654 (USD); RoHS: Yes; Stock: 405000; Supplier: Ozdisan; Supplier Part Number: LMV321ILT
CN1 CN2 = SMD PAD 1: Description: SMD PAD 1; Library Name: ESC_Int.IntLib
CN11 = 2 Pin SMD: Description: 2 Pin SMD; Library Name: ESC_Int.IntLib
